# Altium schematic sheet LowCostUCA.SchDoc
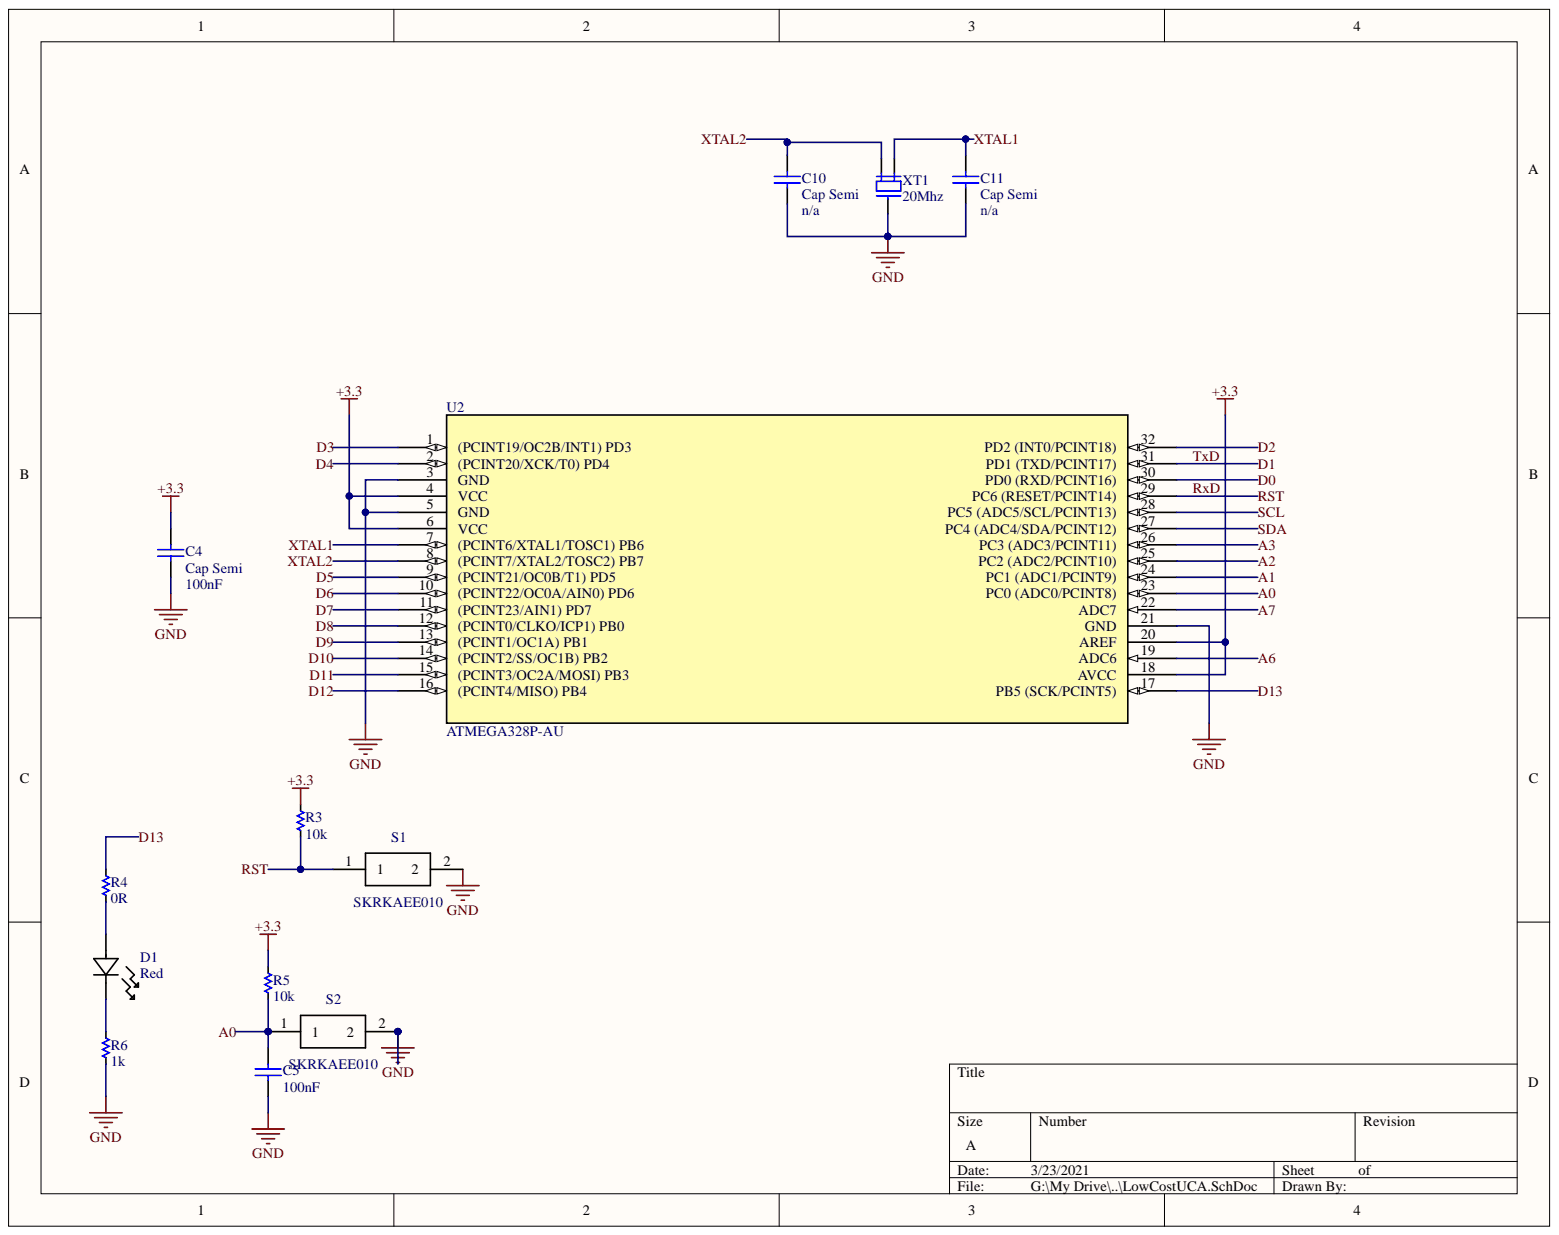
[diagram p0: LowCostUCA.SchDoc]

## Parts
C4  Cap Semi  value 100nF  [1608[0603]]
C5  value 100nF  [1608[0603]]  (hidden comment: Cap Semi)
C10  Cap Semi  [1608[0603]]
C11  Cap Semi  [1608[0603]]
D1  Red  [LED_SML-310]
R3  10k  [RES-SMD-0603]
R4  0R  [RES-SMD-0603]
R5  10k  [RES-SMD-0603]
R6  1k  [RES-SMD-0603]
S1  SKRKAEE010  [*SKRK]
S2  SKRKAEE010  [*SKRK]
U2  ATMEGA328P-AU  [32A_ATM]
XT1  20Mhz  [CRYSTAL CSTCE20M0V53-R0]

## Nets
+3.3: C4.2 R3.1 R5.1 U2.4(VCC) U2.6(VCC) U2.18(AVCC) U2.20(AREF)
GND: C4.1 C5.1 C10.1 C11.1 R6.2 S1.2 S2.2 U2.3(GND) U2.5(GND) U2.21(GND) XT1.2
A0: C5.2 R5.2 S2.1 U2.23(PC0_(ADC0/PCINT8))
A1: U2.24(PC1_(ADC1/PCINT9))
A2: U2.25(PC2_(ADC2/PCINT10))
A3: U2.26(PC3_(ADC3/PCINT11))
A6: U2.19(ADC6)
A7: U2.22(ADC7)
D0: U2.30(PD0_(RXD/PCINT16))
D1: U2.31(PD1_(TXD/PCINT17))
D2: U2.32(PD2_(INT0/PCINT18))
D3: U2.1((PCINT19/OC2B/INT1)_PD3)
D4: U2.2((PCINT20/XCK/T0)_PD4)
D5: U2.9((PCINT21/OC0B/T1)_PD5)
D6: U2.10((PCINT22/OC0A/AIN0)_PD6)
D7: U2.11((PCINT23/AIN1)_PD7)
D8: U2.12((PCINT0/CLKO/ICP1)_PB0)
D9: U2.13((PCINT1/OC1A)_PB1)
D10: U2.14((PCINT2/SS/OC1B)_PB2)
D11: U2.15((PCINT3/OC2A/MOSI)_PB3)
D12: U2.16((PCINT4/MISO)_PB4)
D13: R4.1 U2.17(PB5_(SCK/PCINT5))
RST: R3.2 S1.1 U2.29(PC6_(RESET/PCINT14))
SCL: U2.28(PC5_(ADC5/SCL/PCINT13))
SDA: U2.27(PC4_(ADC4/SDA/PCINT12))
XTAL1: C11.2 U2.7((PCINT6/XTAL1/TOSC1)_PB6) XT1.1
XTAL2: C10.2 U2.8((PCINT7/XTAL2/TOSC2)_PB7) XT1.3
NetD1_1: D1.1 R6.1
NetD1_2: D1.2 R4.2

## Library data of the parts
C4 C5 C10 C11 = Cap Semi: Capacitor (Semiconductor SIM Model); Component Kind: Standard; LatestRevisionDate: 29-May-2009; LatestRevisionNote: IPC-7351 Footprint Added.; Library Name: Miscellaneous Devices.IntLib; PackageDescription: Chip Capacitor; PackageReference: C1206; Published: 8-Jun-2000; Signal Integrity: Cap Semi; Simulation: CAP; Publisher: Altium Limited
  C10 C11: Value: n/a
D1 = SML-311UTT86: No Description Available; Built_By: EMA_Matt; Type: DEV; Vendor: ROHM Semiconductor; Component Kind: Standard; Library Name: Led-SMD0603.IntLib; Manufacturer_Part_Number: SML-311UTT86; RefDes: RefDes; Value: Red; Copyright: Copyright (C) 2018 Accelerated Designs. All rights reserved
R3-R6 = Res-SMD: Resistor; Manufacturer: Generic Components; Class: Passive; Component Kind: Standard; Library Name: LIBRARY.IntLib; Published: 08/06/2000; Publisher: Altium Hobart Technology Centre
  R3 R5: Value: 10k
  R4: Value: 0R
  R6: Value: 1k
S1 S2 = SKRKAEE010: Switch Tactile N.O. SPST Round Button Gull Wing 0.05A 12VDC 1.57N SMD T/R; MF: ALPS; Availability: Unavailable; Component Kind: Standard; Library Name: SKRKAEE010.IntLib; MP: SKRKAEE010; Package: None; Price: None; SnapEDA_Link: https://www.snapeda.com/parts/SKRKAEE010/ALPS/view-part/380772/?ref=snap
U2 = ATMEGA328P-AU: No Description Available; Built_By: EMA_UL_Team; SourceLibrary: Atmel_2011-04-02; Vendor: Atmel; Component Kind: Standard; Library Name: ATMega328P-AU.IntLib; Manufacturer_Part_Number: ATMEGA328P-AU; RefDes: RefDes; Type: DEV; Copyright: Copyright (C) 2018 Accelerated Designs. All rights reserved
XT1 = CRYSTAL 3 Chan: Crystal For all Crystal 2pin.; Value: 4Mhz; Component Kind: Standard; Library Name: lib1.IntLib
